# Altium schematic sheet mtx_ble_48.SchDoc
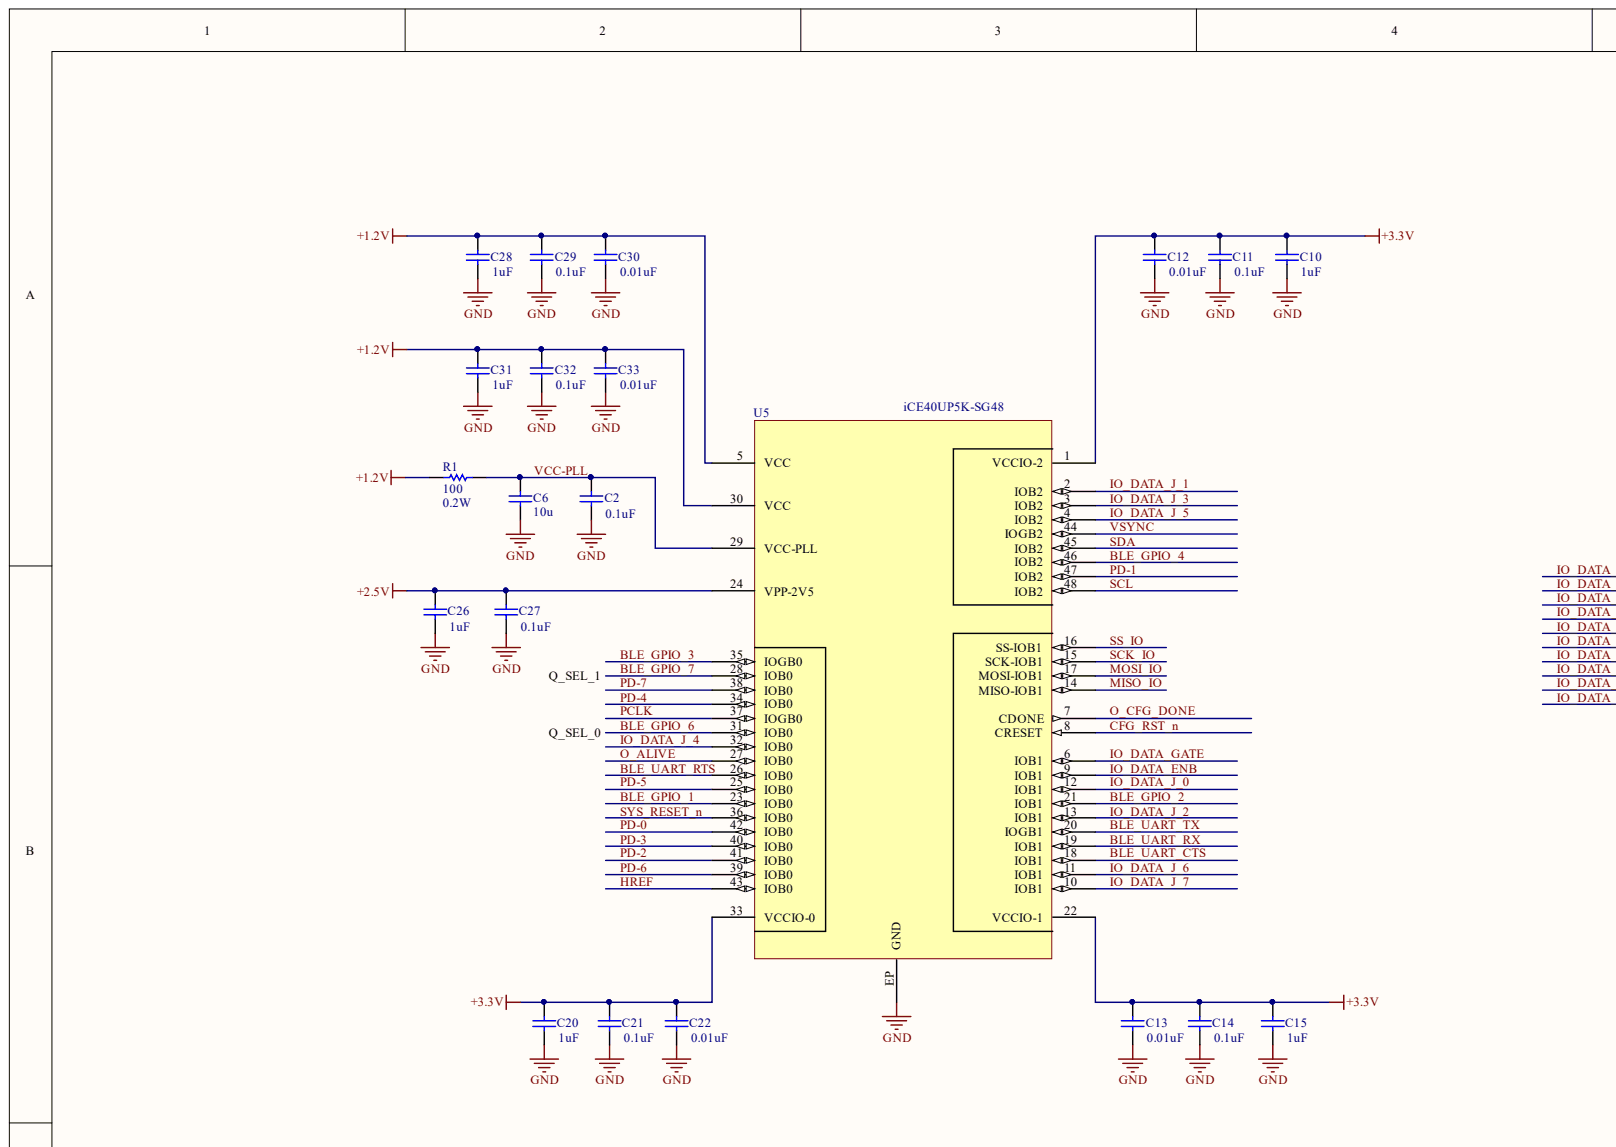
[diagram p0: mtx_ble_48.SchDoc, top left]
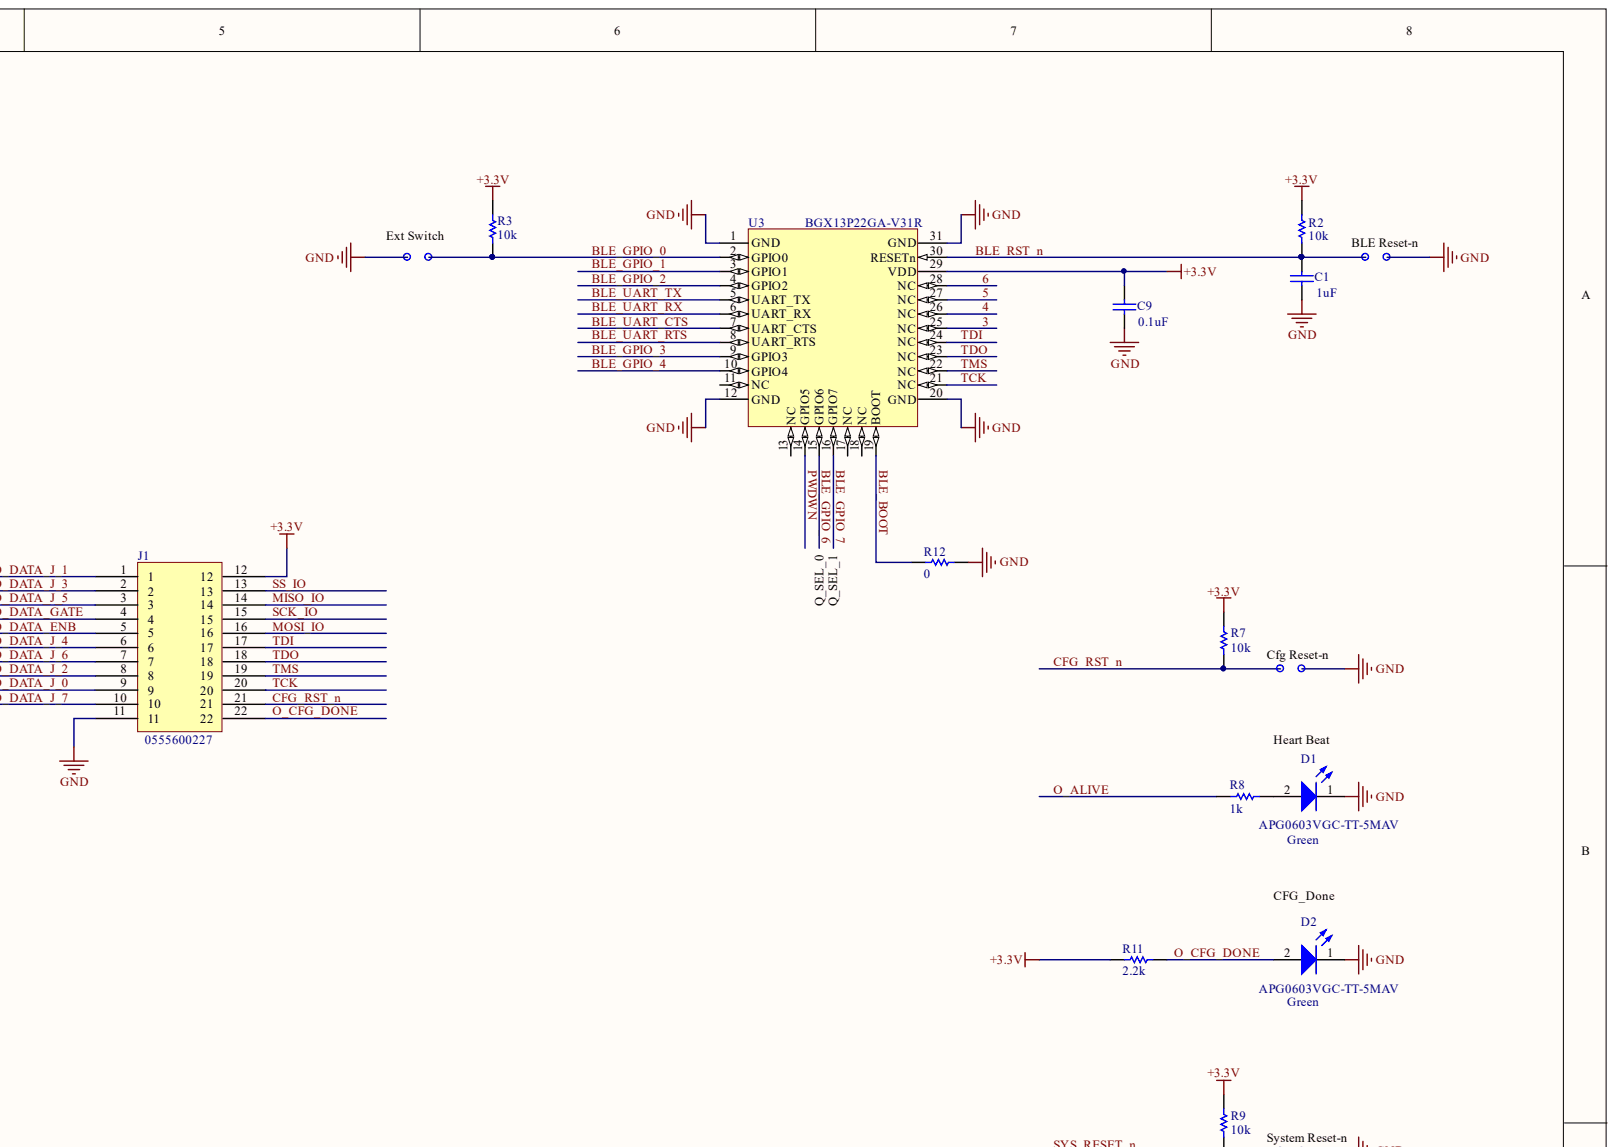
[diagram p1: mtx_ble_48.SchDoc, top right]
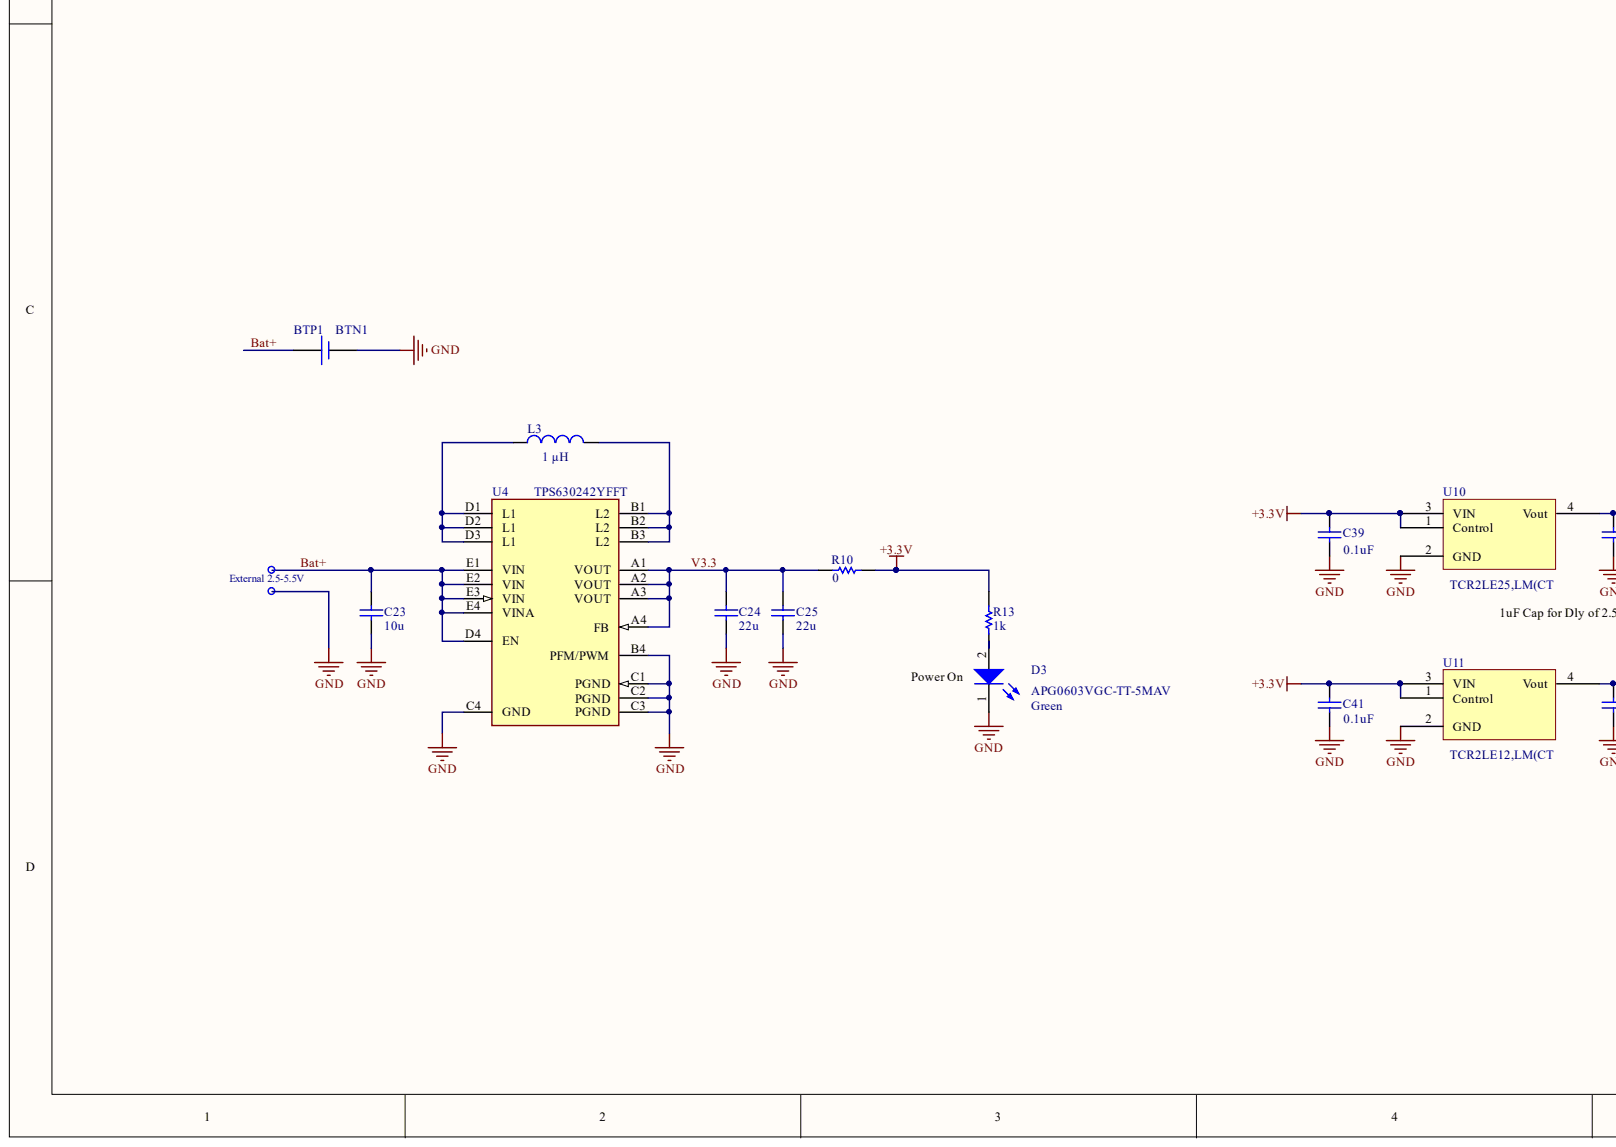
[diagram p2: mtx_ble_48.SchDoc, bottom left]
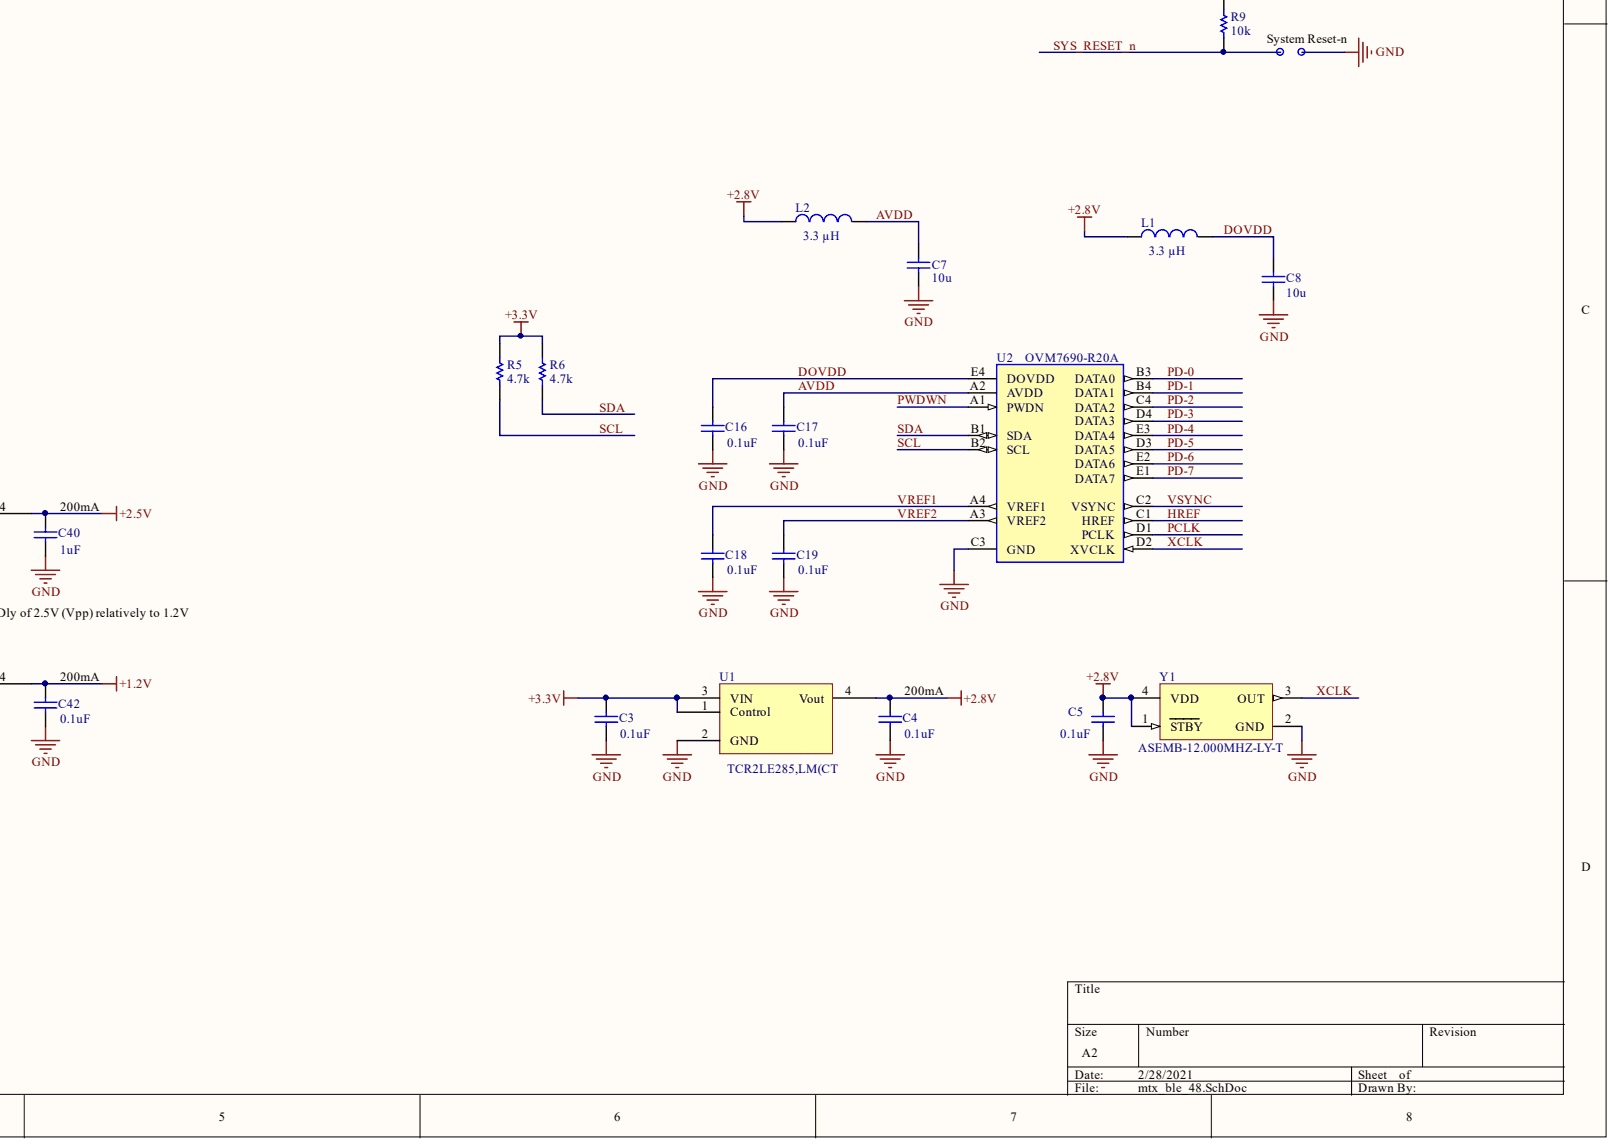
[diagram p3: mtx_ble_48.SchDoc, bottom right]

## Parts
BTN1  Keystone Negative Contact 112TR Mitex  [Coin Cell 20mm]  (value not drawn)
BTP1  Keystone Positive Contact 120TR Mitex  [Coin Cell 20mm]  (value not drawn)
C1  value 1uF  [PCB-8xq37v98r0keo8lap784-1]  (hidden comment: Capacitor MLCC 0201 mitex)
C2  value 0.1uF  [PCB-8xq37v98r0keo8lap784-1]  (hidden comment: Capacitor MLCC 0201 mitex)
C3  value 0.1uF  [PCB-8xq37v98r0keo8lap784-1]  (hidden comment: Capacitor MLCC 0201 mitex)
C4  value 0.1uF  [PCB-8xq37v98r0keo8lap784-1]  (hidden comment: Capacitor MLCC 0201 mitex)
C5  value 0.1uF  [PCB-8xq37v98r0keo8lap784-1]  (hidden comment: Capacitor MLCC 0201 mitex)
C6  value 10u  [PCB-llevxjll59guh2397ncu-1]  (hidden comment: Capacitor MLCC 0402 mitex)
C7  value 10u  [PCB-llevxjll59guh2397ncu-1]  (hidden comment: Capacitor MLCC 0402 mitex)
C8  value 10u  [PCB-llevxjll59guh2397ncu-1]  (hidden comment: Capacitor MLCC 0402 mitex)
C9  value 0.1uF  [PCB-8xq37v98r0keo8lap784-1]  (hidden comment: Capacitor MLCC 0201 mitex)
C10  value 1uF  [PCB-8xq37v98r0keo8lap784-1]  (hidden comment: Capacitor MLCC 0201 mitex)
C11  value 0.1uF  [PCB-8xq37v98r0keo8lap784-1]  (hidden comment: Capacitor MLCC 0201 mitex)
C12  value 0.01uF  [PCB-8xq37v98r0keo8lap784-1]  (hidden comment: Capacitor MLCC 0201 mitex)
C13  value 0.01uF  [PCB-8xq37v98r0keo8lap784-1]  (hidden comment: Capacitor MLCC 0201 mitex)
C14  value 0.1uF  [PCB-8xq37v98r0keo8lap784-1]  (hidden comment: Capacitor MLCC 0201 mitex)
C15  value 1uF  [PCB-8xq37v98r0keo8lap784-1]  (hidden comment: Capacitor MLCC 0201 mitex)
C16  value 0.1uF  [PCB-8xq37v98r0keo8lap784-1]  (hidden comment: Capacitor MLCC 0201 mitex)
C17  value 0.1uF  [PCB-8xq37v98r0keo8lap784-1]  (hidden comment: Capacitor MLCC 0201 mitex)
C18  value 0.1uF  [PCB-8xq37v98r0keo8lap784-1]  (hidden comment: Capacitor MLCC 0201 mitex)
C19  value 0.1uF  [PCB-8xq37v98r0keo8lap784-1]  (hidden comment: Capacitor MLCC 0201 mitex)
C20  value 1uF  [PCB-8xq37v98r0keo8lap784-1]  (hidden comment: Capacitor MLCC 0201 mitex)
C21  value 0.1uF  [PCB-8xq37v98r0keo8lap784-1]  (hidden comment: Capacitor MLCC 0201 mitex)
C22  value 0.01uF  [PCB-8xq37v98r0keo8lap784-1]  (hidden comment: Capacitor MLCC 0201 mitex)
C23  value 10u  [PCB-llevxjll59guh2397ncu-1]  (hidden comment: Capacitor MLCC 0402 mitex)
C24  value 22u  [PCB-llevxjll59guh2397ncu-1]  (hidden comment: Capacitor MLCC 0402 mitex)
C25  value 22u  [PCB-llevxjll59guh2397ncu-1]  (hidden comment: Capacitor MLCC 0402 mitex)
C26  value 1uF  [PCB-8xq37v98r0keo8lap784-1]  (hidden comment: Capacitor MLCC 0201 mitex)
C27  value 0.1uF  [PCB-8xq37v98r0keo8lap784-1]  (hidden comment: Capacitor MLCC 0201 mitex)
C28  value 1uF  [PCB-8xq37v98r0keo8lap784-1]  (hidden comment: Capacitor MLCC 0201 mitex)
C29  value 0.1uF  [PCB-8xq37v98r0keo8lap784-1]  (hidden comment: Capacitor MLCC 0201 mitex)
C30  value 0.01uF  [PCB-8xq37v98r0keo8lap784-1]  (hidden comment: Capacitor MLCC 0201 mitex)
C31  value 1uF  [PCB-8xq37v98r0keo8lap784-1]  (hidden comment: Capacitor MLCC 0201 mitex)
C32  value 0.1uF  [PCB-8xq37v98r0keo8lap784-1]  (hidden comment: Capacitor MLCC 0201 mitex)
C33  value 0.01uF  [PCB-8xq37v98r0keo8lap784-1]  (hidden comment: Capacitor MLCC 0201 mitex)
C39  value 0.1uF  [PCB-8xq37v98r0keo8lap784-1]  (hidden comment: Capacitor MLCC 0201 mitex)
C40  value 1uF  [PCB-8xq37v98r0keo8lap784-1]  (hidden comment: Capacitor MLCC 0201 mitex)
C41  value 0.1uF  [PCB-8xq37v98r0keo8lap784-1]  (hidden comment: Capacitor MLCC 0201 mitex)
C42  value 0.1uF  [PCB-8xq37v98r0keo8lap784-1]  (hidden comment: Capacitor MLCC 0201 mitex)
D1  Color: Green  Manufacturer Part Number: APG0603VGC-TT-5MAV  [Diode]  (hidden comment: LED Kingbright 0201 mitex)
D2  Color: Green  Manufacturer Part Number: APG0603VGC-TT-5MAV  [Diode]  (hidden comment: LED Kingbright 0201 mitex)
D3  Color: Green  Manufacturer Part Number: APG0603VGC-TT-5MAV  [Diode]  (hidden comment: LED Kingbright 0201 mitex)
J1  Manufacturer Part Number: 0555600227  [PCB-6glx67xp3tznom5sg28e-1]  (hidden comment: Molex 0555600227 mitex)
L1  value 3.3 µH  [PCB-byo5il7f8wp7b7f3c12u-1]  (hidden comment: Inductor)
L2  value 3.3 µH  [PCB-byo5il7f8wp7b7f3c12u-1]  (hidden comment: Inductor)
L3  Inductance: 1 µH  [PCB-3oapf9av67z2ydcwvuc1-1]  (hidden comment: Inductor Mitex 1210)
R1  value 100  Power Rating: 0.2W  [PCB-llevxjll59guh2397ncu-1]  (hidden comment: Resistor MLCC 0402 mitex)
R2  value 10k  [RESC0603X26X15ML03T05]  (hidden comment: Resistor SMT 0201 mitex)
R3  value 10k  [RESC0603X26X15ML03T05]  (hidden comment: Resistor SMT 0201 mitex)
R5  value 4.7k  [RESC0603X26X15ML03T05]  (hidden comment: Resistor SMT 0201 mitex)
R6  value 4.7k  [RESC0603X26X15ML03T05]  (hidden comment: Resistor SMT 0201 mitex)
R7  value 10k  [RESC0603X26X15ML03T05]  (hidden comment: Resistor SMT 0201 mitex)
R8  value 1k  [RESC0603X26X15ML03T05]  (hidden comment: Resistor SMT 0201 mitex)
R9  value 10k  [RESC0603X26X15ML03T05]  (hidden comment: Resistor SMT 0201 mitex)
R10  value 0  [PCB-llevxjll59guh2397ncu-1]  (hidden comment: Resistor MLCC 0402 mitex)
R11  value 2.2k  [RESC0603X26X15ML03T05]  (hidden comment: Resistor SMT 0201 mitex)
R12  value 0  [RESC0603X26X15ML03T05]  (hidden comment: Resistor SMT 0201 mitex)
R13  value 1k  [RESC0603X26X15ML03T05]  (hidden comment: Resistor SMT 0201 mitex)
U1  Manufacturer Part Number: TCR2LE285,LM(CT  [PCB-6gdqeko8909jndoqxpdq-1]  (hidden comment: Toshiba TCR2LE28,LM(CT mitex)
U2  Manufacturer Part Number: OVM7690-R20A  [BGA20C50P4X5_247X292X350]  (hidden comment: OmniVision Technologies OVM7690-R20A)
U3  Manufacturer Part Number: BGX13P22GA-V31R  [PCB-6rpwu7uh8lxghdxauyil-1]  (hidden comment: Bluetooth 5 cable replacement BGX13P22GA-V31R mitex)
U4  TPS630242YFFT  [PCB-82dii8s0rofaj23kifkp-1]
U5  Manufacturer Part Number: iCE40UP5K-SG48  [PCB-f08goenydyp8razwcltp-1]  (hidden comment: ice40up_SG48 mitex)
U10  Manufacturer Part Number: TCR2LE25,LM(CT  Voltage - Output (Min/Fixed): 2.5V  [PCB-6gdqeko8909jndoqxpdq-1]  (hidden comment: Toshiba  TCR2LE25,LM(CT mitex)
U11  Manufacturer Part Number: TCR2LE12,LM(CT  [PCB-6gdqeko8909jndoqxpdq-1]  (hidden comment: Toshiba  TCR2LE12,LM(CT mitex)
Y1  Manufacturer Part Number: ASEMB-12.000MHZ-LY-T  [ASEMB Oscillator]  (hidden comment: Abracon ASEMB-12.000MHZ-LY-T mitex)

## Nets
+1.2V: C28.2 C29.2 C30.2 C31.2 C32.2 C33.2 C42.2 R1.2 U5.5(VCC) U5.30(VCC) U11.4(Vout)
+2.5V: C26.2 C27.2 C40.2 U5.24(VPP-2V5) U10.4(Vout)
+2.8V: C4.2 C5.1 L1.1 L2.1 U1.4(Vout) Y1.1(STBY) Y1.4(VDD)
+3.3V: C3.2 C9.2 C10.2 C11.2 C12.2 C13.2 C14.2 C15.2 C20.2 C21.2 C22.2 C39.2 C41.2 J1.12 R2.2 R3.2 R5.2 R6.2 R7.2 R9.2 R10.2 R11.2 R13.2 U1.1(Control) U1.3(VIN) U3.29(VDD) U5.1(VCCIO-2) U5.22(VCCIO-1) U5.33(VCCIO-0) U10.1(Control) U10.3(VIN) U11.1(Control) U11.3(VIN)
GND: BTN1.1 C1.1 C2.1 C3.1 C4.1 C5.2 C6.1 C7.1 C8.1 C9.1 C10.1 C11.1 C12.1 C13.1 C14.1 C15.1 C16.1 C17.1 C18.1 C19.1 C20.1 C21.1 C22.1 C23.2 C24.2 C25.2 C26.1 C27.1 C28.1 C29.1 C30.1 C31.1 C32.1 C33.1 C39.1 C40.1 C41.1 C42.1 D1.1 D2.1 D3.1 J1.11 R12.1 U1.2(GND) U2.C3(GND) U3.1(GND) U3.12(GND) U3.20(GND) U3.31(GND) U4.B4(PFM/PWM) U4.C1(PGND) U4.C2(PGND) U4.C3(PGND) U4.C4(GND) U5.EP(GND) U10.2(GND) U11.2(GND) Y1.2(GND)
3: U3.25(NC)
4: U3.26(NC)
5: U3.27(NC)
6: U3.28(NC)
AVDD: C7.2 C17.2 L2.2 U2.A2(AVDD)
BAT+: BTP1.1 C23.1 U4.D4(EN) U4.E1(VIN) U4.E2(VIN) U4.E3(VIN) U4.E4(VINA)
BLE_BOOT: R12.2 U3.19(BOOT)
BLE_GPIO_0: R3.1 U3.2(GPIO0)
BLE_GPIO_1: U3.3(GPIO1) U5.23(IOB0)
BLE_GPIO_2: U3.4(GPIO2) U5.21(IOB1)
BLE_GPIO_3: U3.9(GPIO3) U5.35(IOGB0)
BLE_GPIO_4: U3.10(GPIO4) U5.46(IOB2)
BLE_GPIO_6: U3.15(GPIO6) U5.31(IOB0)
BLE_GPIO_7: U3.16(GPIO7) U5.28(IOB0)
BLE_RST_N: C1.2 R2.1 U3.30(RESETn)
BLE_UART_CTS: U3.7(UART_CTS) U5.18(IOB1)
BLE_UART_RTS: U3.8(UART_RTS) U5.26(IOB0)
BLE_UART_RX: U3.6(UART_RX) U5.19(IOB1)
BLE_UART_TX: U3.5(UART_TX) U5.20(IOGB1)
CFG_RST_N: J1.21 R7.1 U5.8(CRESET)
DOVDD: C8.2 C16.2 L1.2 U2.E4(DOVDD)
HREF: U2.C1(HREF) U5.43(IOB0)
IO_DATA_ENB: J1.5 U5.9(IOB1)
IO_DATA_GATE: J1.4 U5.6(IOB1)
IO_DATA_J_0: J1.9 U5.12(IOB1)
IO_DATA_J_1: J1.1 U5.2(IOB2)
IO_DATA_J_2: J1.8 U5.13(IOB1)
IO_DATA_J_3: J1.2 U5.3(IOB2)
IO_DATA_J_4: J1.6 U5.32(IOB0)
IO_DATA_J_5: J1.3 U5.4(IOB2)
IO_DATA_J_6: J1.7 U5.11(IOB1)
IO_DATA_J_7: J1.10 U5.10(IOB1)
MISO_IO: J1.14 U5.14(MISO-IOB1)
MOSI_IO: J1.16 U5.17(MOSI-IOB1)
O_ALIVE: R8.2 U5.27(IOB0)
O_CFG_DONE: D2.2 J1.22 R11.1 U5.7(CDONE)
PCLK: U2.D1(PCLK) U5.37(IOGB0)
PD-0: U2.B3(DATA0) U5.42(IOB0)
PD-1: U2.B4(DATA1) U5.47(IOB2)
PD-2: U2.C4(DATA2) U5.41(IOB0)
PD-3: U2.D4(DATA3) U5.40(IOB0)
PD-4: U2.E3(DATA4) U5.34(IOB0)
PD-5: U2.D3(DATA5) U5.25(IOB0)
PD-6: U2.E2(DATA6) U5.39(IOB0)
PD-7: U2.E1(DATA7) U5.38(IOB0)
PWDWN: U2.A1(PWDN) U3.14(GPIO5)
SCK_IO: J1.15 U5.15(SCK-IOB1)
SCL: R5.1 U2.B2(SCL) U5.48(IOB2)
SDA: R6.1 U2.B1(SDA) U5.45(IOB2)
SS_IO: J1.13 U5.16(SS-IOB1)
SYS_RESET_N: R9.1 U5.36(IOB0)
TCK: J1.20 U3.21(NC)
TDI: J1.17 U3.24(NC)
TDO: J1.18 U3.23(NC)
TMS: J1.19 U3.22(NC)
V3.3: C24.1 C25.1 R10.1 U4.A1(VOUT) U4.A2(VOUT) U4.A3(VOUT) U4.A4(FB)
VCC-PLL: C2.2 C6.2 R1.1 U5.29(VCC-PLL)
VREF1: C18.2 U2.A4(VREF1)
VREF2: C19.2 U2.A3(VREF2)
VSYNC: U2.C2(VSYNC) U5.44(IOGB2)
XCLK: U2.D2(XVCLK) Y1.3(OUT)
NetD1_2: D1.2 R8.1
NetD3_2: D3.2 R13.1
NetL3_1: L3.1 U4.D1(L1) U4.D2(L1) U4.D3(L1)
NetL3_2: L3.2 U4.B1(L2) U4.B2(L2) U4.B3(L2)

Not connected: U3 11(NC) 13(NC) 17(NC) 18(NC)

## Library data of the parts
BTN1 = CMP-0135c9d9b1b4def2-10: Stainless Steel Gold Plate Finish Negative Enclosure Contact; Manufacturer: Keystone Electronics; Detailed Description: Battery Contact Spring (Leaf) Multiple 1 Cell SMD (SMT) Tab; Lead-Free Status: Lead Free; Component Kind: Standard; Library Name: CommunityVault; Manufacturer Part Number: 112TR; Mounting Style: Surface Mount, PCB; OctopartID: 0135c9d9b1b4def2; Octopart URL: http://octopart.com/112tr-keystone-31888871; RoHS: Compliant
BTP1 = CMP-0135c9d9b1b4def2-8: Stainless Steel Gold Plate Finish Positive Enclosure Contact; Manufacturer: Keystone Electronics; Detailed Description: Battery Contact Solid (Positive) Multiple 1 Cell SMD (SMT) Tab; Lead-Free Status: Lead Free; Component Kind: Standard; Library Name: CommunityVault; Manufacturer Part Number: 120TR; Mounting Style: Surface Mount, PCB; OctopartID: 0135c9d9b1b4def2; Octopart URL: http://octopart.com/112tr-keystone-31888871; RoHS: Compliant
C1 C10 C15 C20 C26 C28 C31 C40 = CMP-ef00278627f430c7-10: Manufacturer: Murata; Manufacturer Part Number: GRM032R60J105ME05D; Detailed Description: CAP, MLCC, X5R, 1UF, 6.3V, 0201; Temperature Coefficient: X5R; Tolerance: ± 20%; Voltage: 6.3V; Case: 0201; Component Kind: Standard; Description: Capacitor MLCC 0201 mitex; Distributor: Mouser; Library Name: CommunityVault; RoHS: Compliant
C2-C5 C9 C11 C14 C16-C19 C21 C27 C29 C32 C39 C41 C42 = CMP-ef00278627f430c7-10: Manufacturer: TDK; Manufacturer Part Number: C0603X5R1C104K030BC; Detailed Description: 0.1µF ±10% 16V Ceramic Capacitor X5R 0201 (0603 Metric); Temperature Coefficient: X5R; Tolerance: ± 5%; Voltage: 16V; Case: 0201; Component Kind: Standard; Description: Capacitor MLCC 0201 mitex; Distributor: Mouser; Library Name: CommunityVault; RoHS: Compliant
C6-C8 = CMP-55c098f4cfa08a07-6: Manufacturer: Murata Electronics; Manufacturer Part Number: GRM155R60J106ME15D; Detailed Description: 10µF ±20% 6.3V Ceramic Capacitor X5R 0402 (1005 Metric); Temperature Coefficient: X5R; Tolerance: ±20%; Voltage: 6.3V; Case: 0402; Component Kind: Standard; Description: Capacitor MLCC 0402 mitex; Distributor: Digikey; Library Name: CommunityVault
C12 C13 C22 C30 C33 = CMP-ef00278627f430c7-10: Manufacturer: TDK; Manufacturer Part Number: C0603X5R1A103K030BA; Detailed Description: 0.01µF ±10% 10V Ceramic Capacitor X5R 0201 (0603 Metric); Temperature Coefficient: X5R; Tolerance: ± 10%; Voltage: 10V; Case: 0201; Component Kind: Standard; Description: Capacitor MLCC 0201 mitex; Distributor: Mouser; Library Name: CommunityVault; RoHS: Compliant
C23 = CMP-55c098f4cfa08a07-6: Manufacturer: Murata; Manufacturer Part Number: GRM155R60J106ME15D; Detailed Description: 10µF ±20% 6.3V Ceramic Capacitor X5R 0402; Temperature Coefficient: X5R; Tolerance: ±20%; Voltage: 6.3V; Case: 0402; Component Kind: Standard; Description: Capacitor MLCC 0402 mitex; Distributor: Digikey; Library Name: CommunityVault
C24 C25 = CMP-55c098f4cfa08a07-6: Manufacturer: Samsung; Manufacturer Part Number: CL05A226MQ5N6J8; Detailed Description: 22µF ±20% 6.3V Ceramic Capacitor X5R 0402 (1005 Metric); Temperature Coefficient: X5R; Tolerance: ±20%; Voltage: 6.3V; Case: 0402; Component Kind: Standard; Description: Capacitor MLCC 0402 mitex; Distributor: Digikey; Library Name: CommunityVault
D1-D3 = CMP-e67c2b02e6ab39bb-3: Green 0201 140° 80 mcd Clear Surface Mount Chip LED Lamp; Current: 5mA max; Detailed Description: Green 527nm LED Indication - Discrete 3V 2-SMD, 0201; Lead-Free Status: Lead Free; Voltage: 1.97V; Component Kind: Standard; Distributor: Digikey; Library Name: CommunityVault; Manufacturer: Kingbright; OctopartID: e67c2b02e6ab39bb; RoHS: Compliant; Size: 0201
J1 = CMP-6552ac2c9be4ba95-7: 22 Pos Conn 2row 0.5mm Plug Molex; Current Rating: 200 mA; Detailed Description: Mezzanine (Board to Board) Connector Plug 22pos SMD; Number of Circuits: 22; Component Kind: Standard; Connector Type: Plug; Library Name: CommunityVault; Manufacturer: Molex; Mounting Style: Surface Mount; Number of Rows: 1; OctopartID: 6552ac2c9be4ba95; Octopart URL: http://octopart.com/501920-3001-molex-7658448; Orientation: Vertical
L1 L2 = CMP-9fe657dd5fdb4021-2: Inductor Power Chip Wirewound 3.3uH 20% 1MHz Ferrite 0.87A 0.221Ohm DCR 0805 T/R; Manufacturer: Taiyo Yuden; Manufacturer Part Number: BRC2012T3R3MD; Detailed Description: 3.3µH Unshielded Wirewound Inductor 870mA 221mOhm Max 0805; Self Resonant Frequency: 300 MHz; Case/Package: 0805; Component Kind: Standard; Library Name: CommunityVault; Mounting Style: Surface Mount
L3 = CMP-a6c26ed794787905-2: Manufacturer: Murata; Manufacturer Part Number: DFE322512C; Detailed Description: Shielded Wirewound Inductor 45mOhm Max 1210 (3225 Metric); Case: 1210; Component Kind: Standard; Description: Inductor Mitex 1210; Library Name: CommunityVault; OctopartID: a6c26ed794787905; Package / Case: 1210 (3225 Metric)
R1 = CMP-55c098f4cfa08a07-7: Manufacturer: Vishay Dale; Manufacturer Part Number: RCS0402100RJNED; Detailed Description: Thick Film Resistors - SMD 100 Ohms ±5% 0.2W, 1/5W Chip Resistor 0402; Case: 0402; Component Kind: Standard; Description: Resistor MLCC 0402 mitex; Distributor: Digikey; Library Name: CommunityVault
R2 R3 R7 R9 = CMP-1848359-9: Resistor SMT 0201 Generic mitex; Manufacturer: Panasonic; Manufacturer Part Number: ERJ-1GEF1002C; Detailed Description: 10 kOhms ±1% 0.05W, 1/20W Chip Resistor 0201 (0603 Metric) Thick Film; Lead-Free Status: Lead Free; Power Rating: 0.05 W; Tolerance: ±1%; Component Kind: Standard; Distributor: Digikey; Library Name: CommunityVault; RoHS: Compliant; Size: 0201
R5 R6 = CMP-1848359-9: Resistor SMT 0201 Generic mitex; Manufacturer: Panasonic; Manufacturer Part Number: ERJ-1GEF4701C; Detailed Description: 4.7 kOhms ±1% 0.05W, 1/20W Chip Resistor 0201 (0603 Metric) Thick Film; Lead-Free Status: Lead Free; Power Rating: 0.05 W; Tolerance: ±1%; Component Kind: Standard; Distributor: Digikey; Library Name: CommunityVault; RoHS: Compliant; Size: 0201
R8 R13 = CMP-1848359-9: Resistor SMT 0201 Generic mitex; Manufacturer: Panasonic; Manufacturer Part Number: ERJ-1GEF1001C; Detailed Description: 1 kOhms ±1% 0.05W, 1/20W Chip Resistor 0201 (0603 Metric) Thick Film; Lead-Free Status: Lead Free; Power Rating: 0.05 W; Tolerance: ±1%; Component Kind: Standard; Distributor: Digikey; Library Name: CommunityVault; RoHS: Compliant; Size: 0201
R10 = CMP-55c098f4cfa08a07-7: Manufacturer: Panasonic; Manufacturer Part Number: ERJ-S020R00X; Detailed Description: Thick Film Resistors - SMD 100 Ohms ±5% 0.2W, 1/5W Chip Resistor 0402; Power Rating: 0.1W; Case: 0402; Component Kind: Standard; Description: Resistor MLCC 0402 mitex; Distributor: Digikey; Library Name: CommunityVault
R11 = CMP-1848359-9: Resistor SMT 0201 Generic mitex; Manufacturer: Panasonic; Manufacturer Part Number: ERJ-1GNF2201C; Detailed Description: 2.2 kOhms ±1% 0.05W, 1/20W Chip Resistor 0201 (0603 Metric) Thick Film; Lead-Free Status: Lead Free; Power Rating: 0.05 W; Tolerance: ±1%; Component Kind: Standard; Distributor: Digikey; Library Name: CommunityVault; RoHS: Compliant; Size: 0201
R12 = CMP-1848359-9: Resistor SMT 0201 Generic mitex; Manufacturer: Panasonic; Manufacturer Part Number: ERJ-U010R00C; Detailed Description: 0 Ohms ±1% 0.05W, 1/20W Chip Resistor 0201 (0603 Metric) Thick Film; Lead-Free Status: Lead Free; Power Rating: 0.05 W; Tolerance: ±1%; Component Kind: Standard; Distributor: Digikey; Library Name: CommunityVault; RoHS: Compliant; Size: 0201
U1 = CMP-9d88572d9a8f424f-4: LDO Regulator Pos 2.8V 0.2A 5-Pin ESV T/R; Current - Output: 200mA; Detailed Description: LDO 2.8V Output 200mA; Voltage - Input: 1.5v - 5.5V; Voltage Dropout (Max): 0.38V @ 150mA; Component Kind: Standard; Library Name: CommunityVault; Manufacturer: Toshiba; Package / Case: SOT-553; Voltage - Output (Min/Fixed): 2.8V
U2 = CMP-2125528-8: SENSOR CAMERA CMOS 20CAMERACUBE; Detailed Description: CMOS with Processor Image Sensor 640H x 480V 1.75µm x 1.75µm; Component Kind: Standard; Library Name: CommunityVault; Manufacturer: OmniVision Technologies
U3 = CMP-72d0bd5c6f16ad17-1: Bluetooth 5 cable replacement; Manufacturer: Silicon Labs; Detailed Description: Bluetooth Bluetooth v5.0 Transceiver Module; Component Kind: Standard; Library Name: CommunityVault; OctopartID: 72d0bd5c6f16ad17; Octopart URL: https://octopart.com/bgx13p22ga-v31r-silicon+labs-109459616
U4 = CMP-77f9bdedd42da83e-8: TI 2.5v-5.5v to 2.9v High Current, High Efficiency Single Inductor Buck-Boost Converter; Manufacturer: Texas Instruments; Current - Output: 2.12A (Switch); Detailed Description: Buck-Boost Switching Regulator IC Positive Fixed 3.3V 1 Output 2.12A (Switch) 20-UFBGA, DSBGA; Frequency - Switching: 2.5 MHz; Function: Step-Up/Step-Down; Voltage - Input: 2.3V - 5.5V; Voltage - Output: 3.3V; Component Kind: Standard; Library Name: CommunityVault; Manufacturer Part Number: TPS630242YFFT; Operating Temperature: -40°C ~ 85°C; Package / Case: 20-UFBGA, DSBGA; Topology: Buck-Boost
U5 = CMP-a6kpobpizyxqg6dolens-16: FPGA ice40up_SG48   39 I/O 48QFN 7x7; Manufacturer: Lattice Semiconductor Corporation; Detailed Description: FPGA 39 I/O 48QFN; Component Kind: Standard; Library Name: CommunityVault; Package / Case: 48-VFQFN Exposed Pad (7 x 7)
U10 = CMP-9d88572d9a8f424f-4: LDO Regulator Pos 2.5V 0.2A 5-Pin ESV T/R; Current - Output: 200mA; Detailed Description: LDO 2.5V Output 200mA; Voltage - Input: 1.5v - 5.5V; Voltage Dropout (Max): 0.38V @ 150mA; Component Kind: Standard; Library Name: CommunityVault; Manufacturer: Toshiba; Package / Case: SOT-553
U11 = CMP-9d88572d9a8f424f-4: LDO Regulator Pos 1.2V 0.2A 5-Pin ESV T/R; Current - Output: 200mA; Detailed Description: LDO 1.2V Output 200mA; Voltage - Input: 1.5v - 5.5V; Voltage Dropout (Max): 1.25V @ 150mA; Component Kind: Standard; Library Name: CommunityVault; Manufacturer: Toshiba; Package / Case: SOT-553; Voltage - Output (Min/Fixed): 1.2V
Y1 = CMP-6b71a34bcf51b4b6-2: Oscillator MEMS 12MHz ±10ppm (Stability) 15pF LVCMOS 55% 1.8V/2.5V/3.3V Automotive 4-Pin QFN SMD T/R; Detailed Description: 12MHz XO (Standard) CMOS Oscillator 1.8V ~ 3.3V Standby (Power Down) 4-SMD, No Lead; Lead-Free Status: Lead Free; Component Kind: Standard; Library Name: CommunityVault; Manufacturer: Abracon; Mounting Style: Surface Mount; OctopartID: 6b71a34bcf51b4b6; Octopart URL: https://octopart.com/asemb-12.000mhz-ly-t-abracon-19956038; Operating Temperature: -40.0 °C (min); Packaging: Tape & Reel (TR); RoHS: Compliant
